# Altium schematic sheet Project_Board.SchDoc
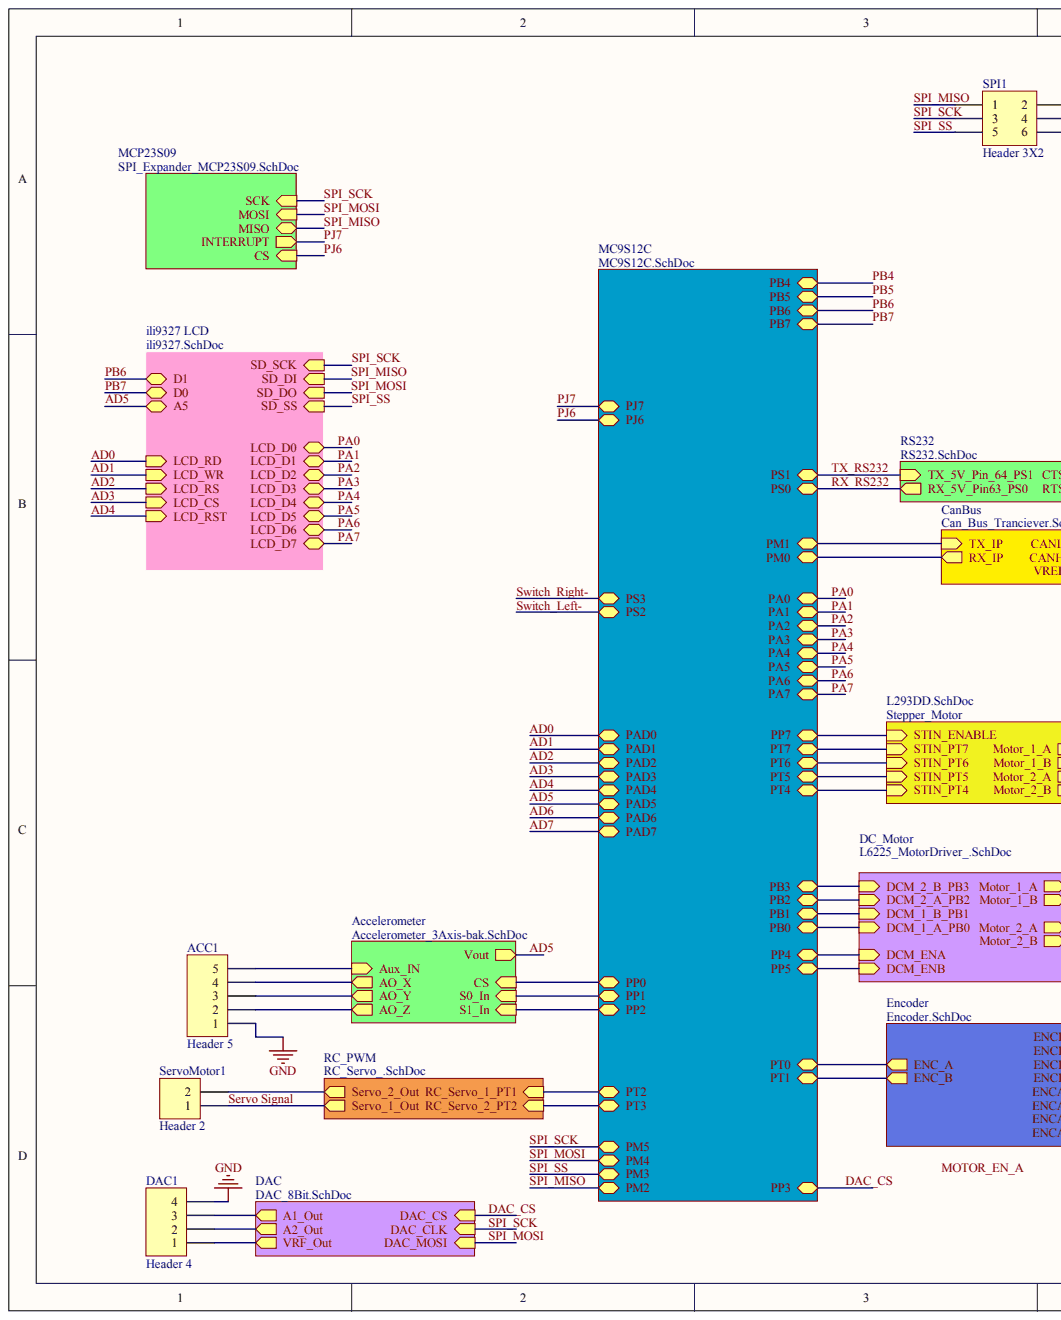
[diagram p0: Project_Board.SchDoc, left]
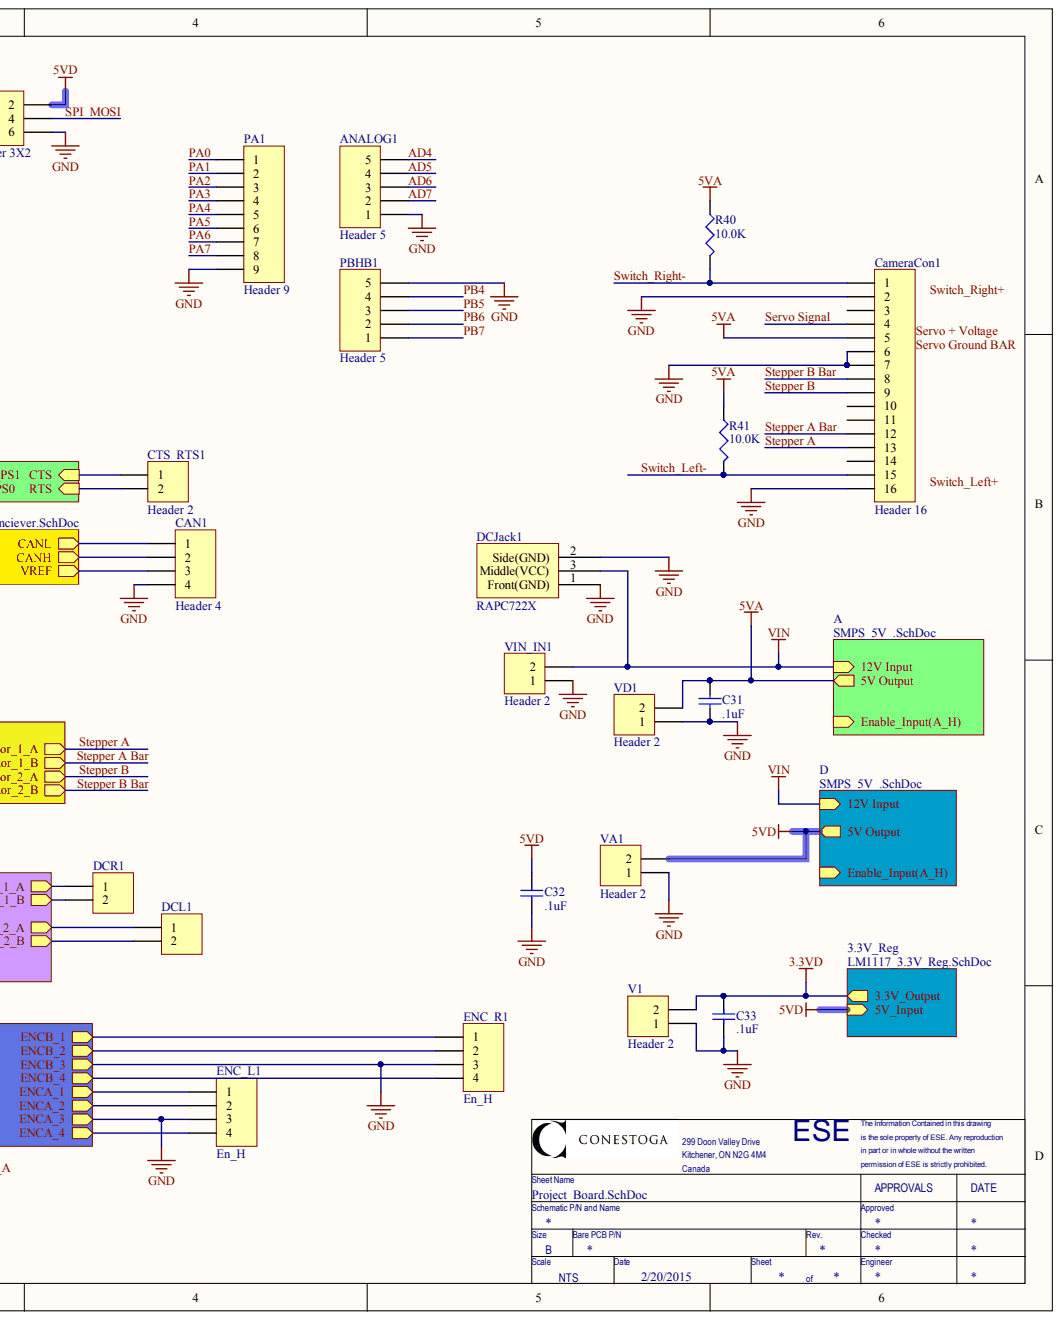
[diagram p1: Project_Board.SchDoc, right]

## Parts
ACC1  Header 5  [HDR1X5]
ANALOG1  Header 5  [HDR1X5]
C31  value .1uF  [CAPC2012X09M]  (hidden comment: Cap)
C32  value .1uF  [CAPC2012X09M]  (hidden comment: Cap)
C33  value .1uF  [CAPC2012X09M]  (hidden comment: Cap)
CameraCon1  Header 16  [HDR1X16]
CAN1  Header 4  [HDR1X4]
CTS_RTS1  Header 2  [HDR1X2]
DAC1  Header 4  [HDR1X4]
DCJack1  RAPC722X  [BGA56x10]
DCL1  Header 2  [HDR1X2]  (value not drawn)
DCR1  Header 2  [HDR1X2]  (value not drawn)
ENC_L1  En_H  [HDR1X4]
ENC_R1  En_H  [HDR1X4]
PA1  Header 9  [HDR1X9]
PBHB1  Header 5  [HDR1X5]
R40  value 10.0K  [RESC2112X05M]  (hidden comment: Res3)
R41  value 10.0K  [RESC2112X05M]  (hidden comment: Res3)
ServoMotor1  Header 2  [HDR1X2]
SPI1  Header 3X2  [HDR2X3]
V1  Header 2  [HDR1X2]
VA1  Header 2  [HDR1X2]
VD1  Header 2  [HDR1X2]
VIN_IN1  Header 2  [HDR1X2]

## Nets
3.3VD: C33.2 V1.2
5VA: C31.2 CameraCon1.5 R40.1 R41.1 VD1.2
5VD: C32.2 SPI1.2 VA1.2
GND: ACC1.1 ANALOG1.1 C31.1 C32.1 C33.1 CameraCon1.2 CameraCon1.6 CameraCon1.7 CameraCon1.16 CAN1.4 DAC1.4 DCJack1.1 DCJack1.2 ENC_L1.3 ENC_R1.3 PA1.9 PBHB1.5 SPI1.6 V1.1 VA1.1 VD1.1 VIN_IN1.1
VIN: DCJack1.3 VIN_IN1.2
AD4: ANALOG1.5
AD5: ANALOG1.4
AD6: ANALOG1.3
AD7: ANALOG1.2
PA0: PA1.1
PA1: PA1.2
PA2: PA1.3
PA3: PA1.4
PA4: PA1.5
PA5: PA1.6
PA6: PA1.7
PA7: PA1.8
PB4: PBHB1.4
PB5: PBHB1.3
PB6: PBHB1.2
PB7: PBHB1.1
SERVO SIGNAL: CameraCon1.4 ServoMotor1.1
SPI_MISO: SPI1.1
SPI_MOSI: SPI1.4
SPI_SCK: SPI1.3
SPI_SS: SPI1.5
STEPPER A: CameraCon1.13
STEPPER A BAR: CameraCon1.12
STEPPER B: CameraCon1.9
STEPPER B BAR: CameraCon1.8
SWITCH_LEFT-: CameraCon1.15 R41.2
SWITCH_RIGHT-: CameraCon1.1 R40.2
NetACC1_2: ACC1.2
NetACC1_3: ACC1.3
NetACC1_4: ACC1.4
NetACC1_5: ACC1.5
NetCameraCon1_3: CameraCon1.3
NetCameraCon1_10: CameraCon1.10
NetCameraCon1_11: CameraCon1.11
NetCameraCon1_14: CameraCon1.14
NetCAN1_1: CAN1.1
NetCAN1_2: CAN1.2
NetCAN1_3: CAN1.3
NetCTS_RTS1_1: CTS_RTS1.1
NetCTS_RTS1_2: CTS_RTS1.2
NetDAC1_1: DAC1.1
NetDAC1_2: DAC1.2
NetDAC1_3: DAC1.3
NetDCL1_1: DCL1.1
NetDCL1_2: DCL1.2
NetDCR1_1: DCR1.1
NetDCR1_2: DCR1.2
NetENC_L1_1: ENC_L1.1
NetENC_L1_2: ENC_L1.2
NetENC_L1_4: ENC_L1.4
NetENC_R1_1: ENC_R1.1
NetENC_R1_2: ENC_R1.2
NetENC_R1_4: ENC_R1.4
NetServoMotor1_2: ServoMotor1.2
AD0:
AD1:
AD2:
AD3:
CS:
DAC_CS:
DCM_1_A_PB0:
DCM_1_B_PB1:
DCM_2_A_PB2:
DCM_2_B_PB3:
DCM_ENA:
DCM_ENB:
ENABLE_INPUT(A_H):
ENC_A:
ENC_B:
MOSI:
MOTOR_EN_A:
PJ6:
PJ7:
PP7:
PT2:
PT3:
PT4:
PT5:
PT6:
PT7:
RX_IP:
RX_RS232:
S0_IN:
S1_IN:
SERVO + VOLTAGE:
SERVO GROUND BAR:
SWITCH_LEFT+:
SWITCH_RIGHT+:
TX_IP:
TX_RS232:

## Library data of the parts
ACC1 ANALOG1 PBHB1 = Header 5: Header, 5-Pin; Component Kind: Standard; LatestRevisionDate: 17-Jul-2002; LatestRevisionNote: Re-released for DXP Platform.; Library Name: Miscellaneous Connectors.IntLib; Signal Integrity: Connector; Publisher: Altium Limited
C31-C33 = CAP-Z5U-.1uF-50V-±20%: CAP CER .1uF 50v Z5U 0805; MPN: C0805C104M5UACTU; PN: 399-1176-1-ND; Tolerance: ±20%; WV: 50; Component Kind: Standard; Library Name: esd_library.IntLib; Name: CAP-Z5U-.1uF-50V-±20%; Type: Z5U; URL: http://www.digikey.ca/product-detail/en/C0805C104M5UACTU/399-1176-1-ND
CameraCon1 = Header 16: Header, 16-Pin; Component Kind: Standard; LatestRevisionDate: 17-Jul-2002; LatestRevisionNote: Re-released for DXP Platform.; Library Name: Miscellaneous Connectors.IntLib; Signal Integrity: Connector; Publisher: Altium Limited
CAN1 DAC1 ENC_L1 ENC_R1 = Header 4: Header, 4-Pin; Component Kind: Standard; LatestRevisionDate: 17-Jul-2002; LatestRevisionNote: Re-released for DXP Platform.; Library Name: Miscellaneous Connectors.IntLib; Signal Integrity: Connector; Publisher: Altium Limited
CTS_RTS1 ServoMotor1 V1 VA1 VD1 VIN_IN1 = Header 2: Header, 2-Pin; PN: S1212E-02-ND; Component Kind: Standard; Library Name: esd_library.IntLib; Signal Integrity: Connector; URL: http://www.digikey.ca/product-detail/en/PEC04SFAN/S1212E-02-ND
DCL1 DCR1 = Header 2: Header, 2-Pin; MPN: PEC02SFAN; PN: S1212E-02-ND; Component Kind: Standard; Library Name: esd_library.IntLib; Signal Integrity: Connector; URL: http://www.digikey.ca/product-detail/en/PEC04SFAN/S1212E-02-ND
PA1 = Header 9: Header, 9-Pin; Component Kind: Standard; LatestRevisionDate: 17-Jul-2002; LatestRevisionNote: Re-released for DXP Platform.; Library Name: Miscellaneous Connectors.IntLib; Signal Integrity: Connector; Publisher: Altium Limited
R40 R41 = Resistor_10.0K: RES SMD 10.0K OHM 1% 1/8W 0805; MPN: CRCW080510K0FKEA; PN: 541-10.0KCCT-ND; Component Kind: Standard; Library Name: esd_library.IntLib; Name: Resistor_10.0K; URL: http://www.digikey.ca/product-detail/en/CRCW080510K0FKEA/541-10.0KCCT-ND
SPI1 = Header 3X2: Header, 3-Pin, Dual row; Component Kind: Standard; LatestRevisionDate: 17-Jul-2002; LatestRevisionNote: Re-released for DXP Platform.; Library Name: Miscellaneous Connectors.IntLib; Signal Integrity: Connector; Publisher: Altium Limited
DCJack1 = RAPC722X: Component Kind: Standard; Library Name: Crystal.SchLib; URL: http://www.digikey.com/product-detail/en/RAPC722X/SC1313-ND/804747
